# Altium schematic sheet MM-Esp32S3.SchDoc
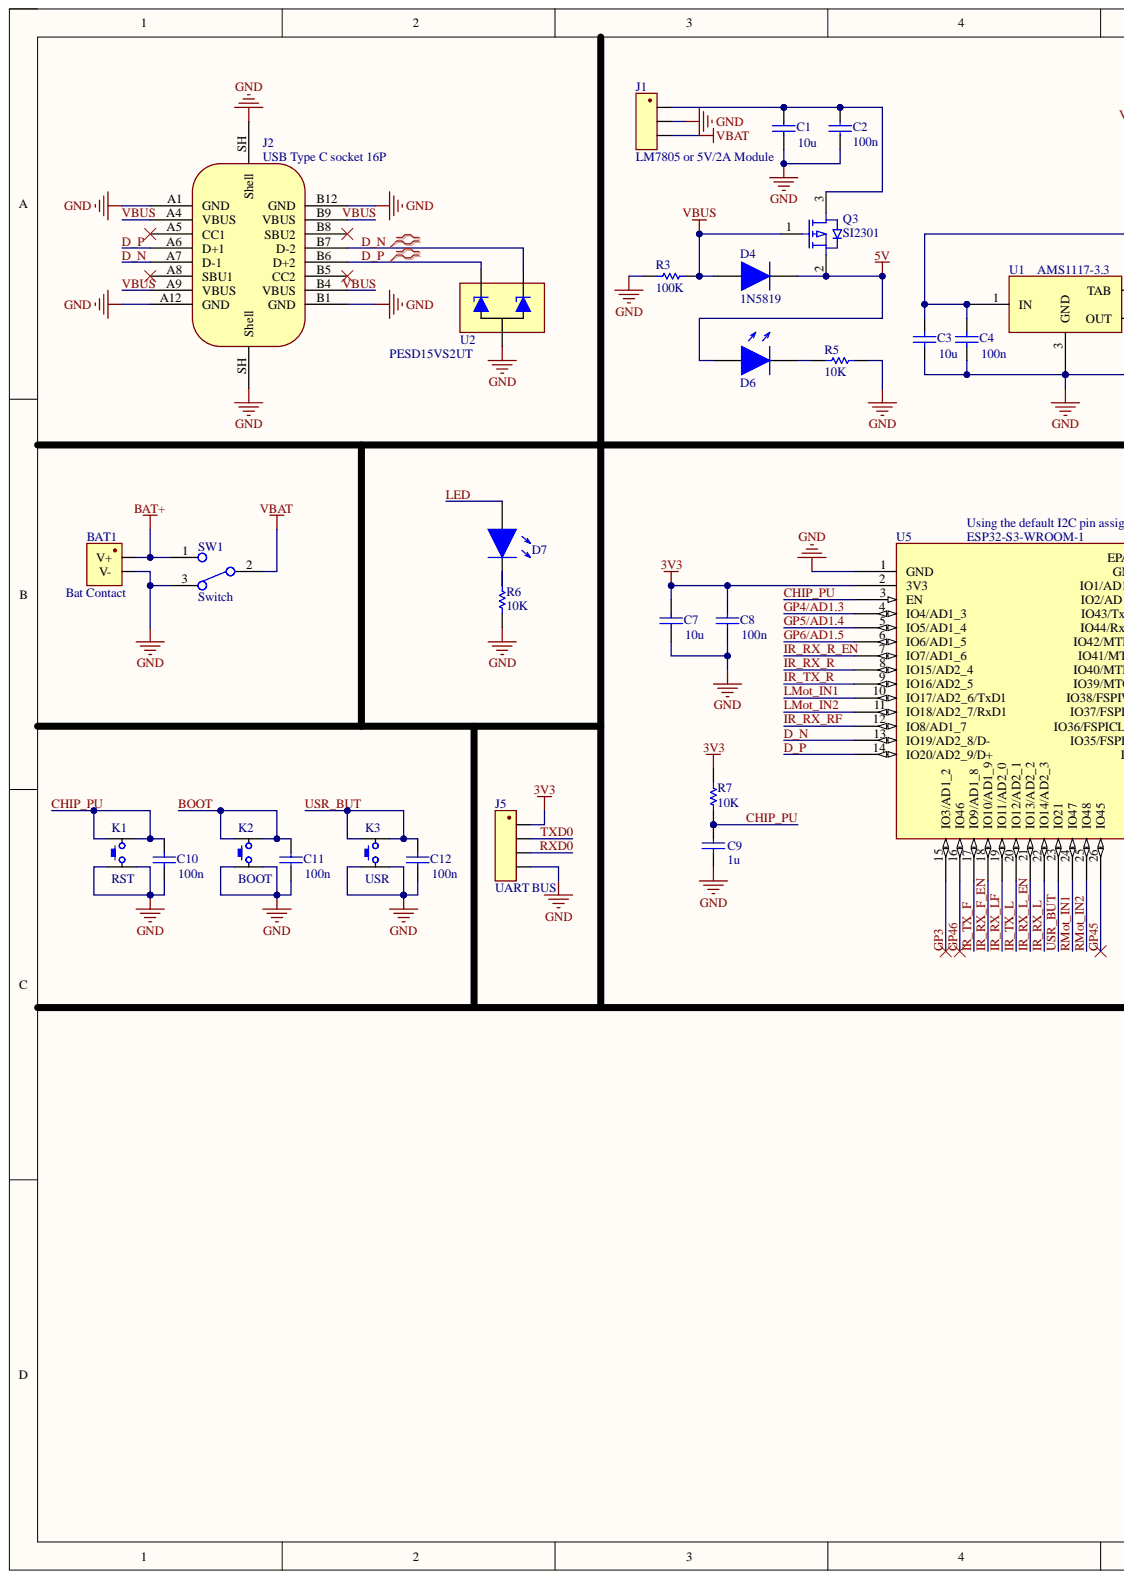
[diagram p0: MM-Esp32S3.SchDoc, left]
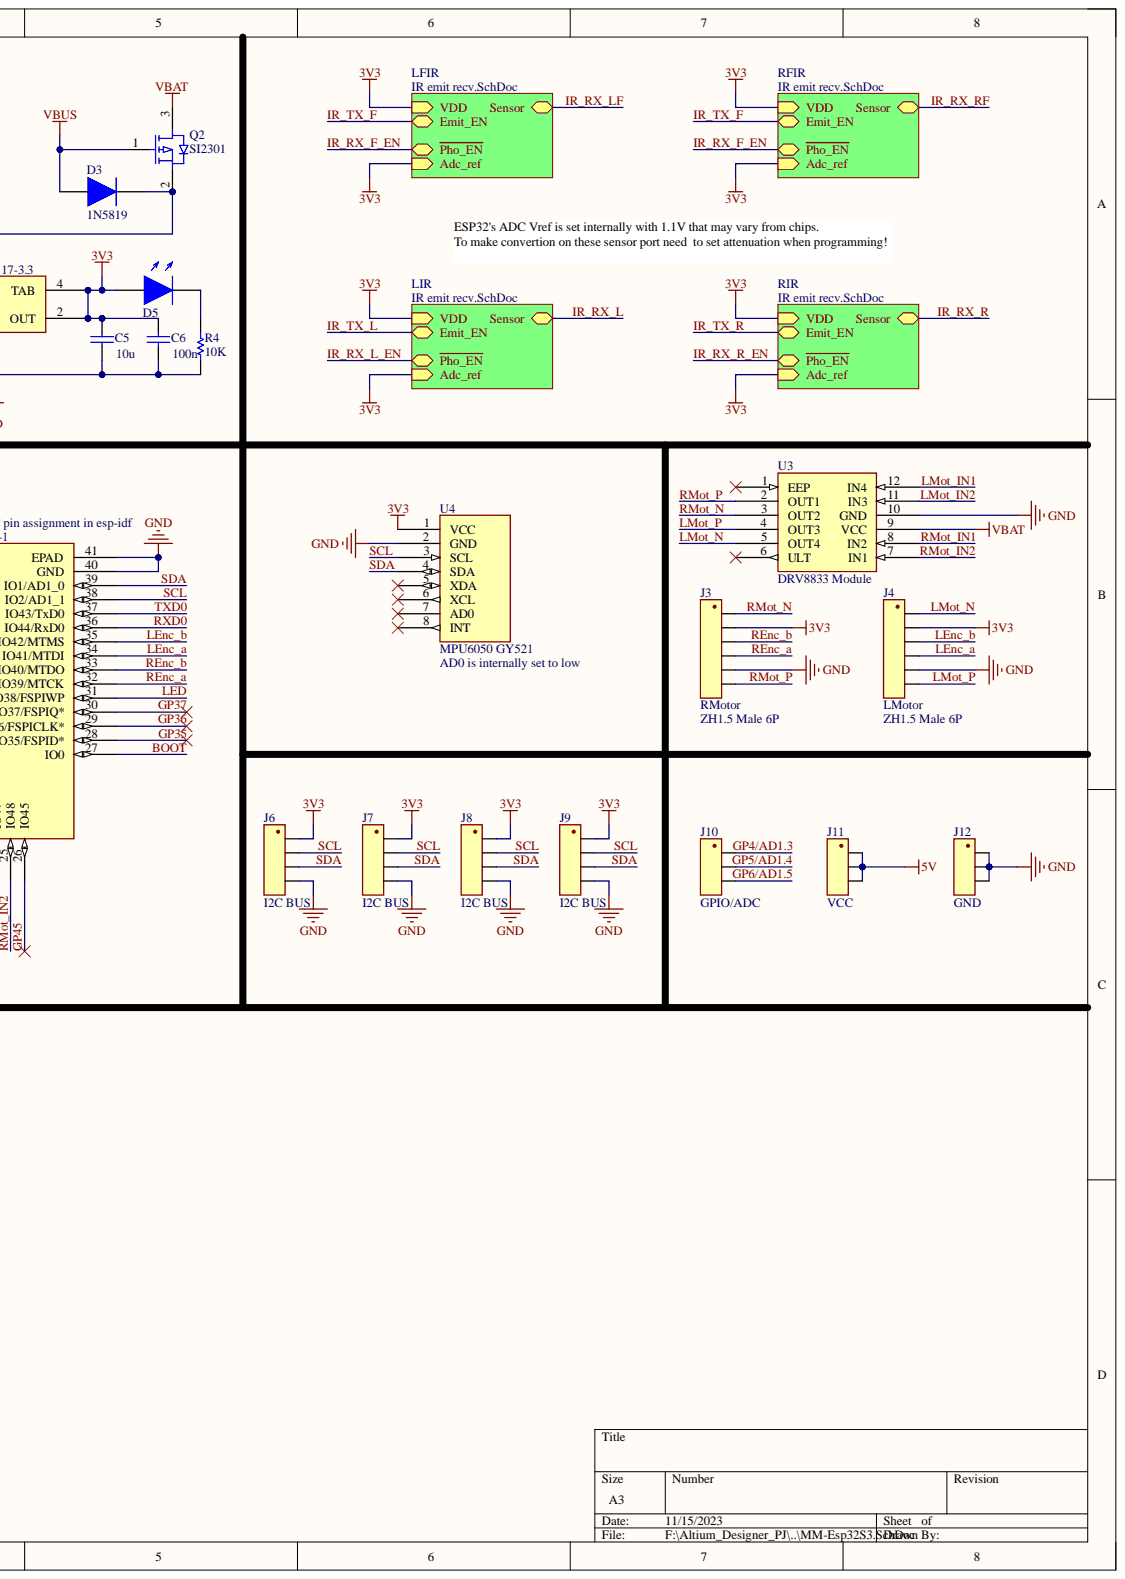
[diagram p1: MM-Esp32S3.SchDoc, right]

## Parts
BAT1  Bat Contact  [9V BAT SNAP-ON 2 CONTACTS MF]
C1  value 10u  [0805 Cap]  (hidden comment: Cap)
C2  value 100n  [0805 Cap]  (hidden comment: Cap)
C3  value 10u  [0805 Cap]  (hidden comment: Cap)
C4  value 100n  [0805 Cap]  (hidden comment: Cap)
C5  value 10u  [0805 Cap]  (hidden comment: Cap)
C6  value 100n  [0805 Cap]  (hidden comment: Cap)
C7  value 10u  [0805 Cap]  (hidden comment: Cap)
C8  value 100n  [0805 Cap]  (hidden comment: Cap)
C9  value 1u  [0805 Cap]  (hidden comment: Cap)
C10  value 100n  [0805 Cap]  (hidden comment: Cap)
C11  value 100n  [0805 Cap]  (hidden comment: Cap)
C12  value 100n  [0805 Cap]  (hidden comment: Cap)
D3  1N5819  [SOD323 0805]
D4  1N5819  [SOD323 0805]
D5  LED  [LED 3mm]  (value not drawn)
D6  LED  [LED 3mm]  (value not drawn)
D7  LED  [LED 3mm]
J1  LM7805 or 5V/2A Module  [Header 3Pins Female DIP]
J2  USB Type C socket 16P  [USB Type C 16P Through hole]
J3  RMotor  Size: ZH1.5 Male 6P  [ZH1.5 6PINS DIP]
J4  LMotor  Size: ZH1.5 Male 6P  [ZH1.5 6PINS DIP]
J5  UART BUS  [Header 4Pins DIP]
J6  I2C BUS  [Header 4Pins DIP]
J7  I2C BUS  [Header 4Pins DIP]
J8  I2C BUS  [Header 4Pins DIP]
J9  I2C BUS  [Header 4Pins DIP]
J10  GPIO/ADC  [Header 3Pins DIP]
J11  VCC  [Header 3Pins DIP]
J12  GND  [Header 3Pins DIP]
K1  RST  [BUTTON TH 6*6*5]
K2  BOOT  [BUTTON TH 6*6*5]
K3  USR  [BUTTON TH 6*6*5]
Q2  SI2301  [SOT23-3]
Q3  SI2301  [SOT23-3]
R3  value 100K  [0805 R/L]  (hidden comment: Res)
R4  value 10K  [0805 R/L]  (hidden comment: Res)
R5  value 10K  [0805 R/L]  (hidden comment: Res)
R6  value 10K  [0805 R/L]  (hidden comment: Res)
R7  value 10K  [0805 R/L]  (hidden comment: Res)
SW1  Switch  [Slide switch]
U1  AMS1117-3.3  [SOT-223]
U2  PESD15VS2UT  [SOT23-3]
U3  DRV8833 Module  [DRV8833 MODULE]
U4  MPU6050 GY521  [MPU6050 Module]
U5  ESP32-S3-WROOM-1  [ESP32-WROOM]

## Nets
3V3: C5.2 C6.2 C7.2 C8.2 D5.2 J3.2 J4.2 J5.1 J6.1 J7.1 J8.1 J9.1 R7.1 U1.2(OUT) U1.4(TAB) U4.1(VCC) U5.2(3V3)
5V: D4.2 D6.2 J11.1 J11.2 J11.3 Q3.2
BAT+: BAT1.1 SW1.1
GND: BAT1.2 C1.1 C2.1 C3.1 C4.1 C5.1 C6.1 C7.1 C8.1 C9.1 C10.1 C11.1 C12.1 J1.2 J2.A1(GND) J2.A12(GND) J2.B1(GND) J2.B12(GND) J2.SH(Shell) J3.5 J4.5 J5.4 J6.4 J7.4 J8.4 J9.4 J12.1 J12.2 J12.3 K1.3 K1.4 K2.3 K2.4 K3.3 K3.4 R3.2 R4.2 R5.2 R6.2 SW1.3 U1.3(GND) U2.3 U3.10(GND) U4.2(GND) U5.1(GND) U5.40(GND) U5.41(EPAD)
VBAT: J1.3 Q2.3 SW1.2 U3.9(VCC)
BOOT: C11.2 K2.1 K2.2 U5.27(IO0)
CHIP_PU: C9.2 C10.2 K1.1 K1.2 R7.2 U5.3(EN)
D_N: J2.A7(D-1) J2.B7(D-2) U2.1 U5.13(IO19/AD2_8/D-)
D_P: J2.A6(D+1) J2.B6(D+2) U2.2 U5.14(IO20/AD2_9/D+)
GP3: U5.15(IO3/AD1_2)
GP4/AD1.3: J10.1 U5.4(IO4/AD1_3)
GP5/AD1.4: J10.2 U5.5(IO5/AD1_4)
GP6/AD1.5: J10.3 U5.6(IO6/AD1_5)
GP35: U5.28(IO35/FSPID*)
GP36: U5.29(IO36/FSPICLK*)
GP37: U5.30(IO37/FSPIQ*)
GP45: U5.26(IO45)
GP46: U5.16(IO46)
IR_RX_F_EN: U5.18(IO10/AD1_9)
IR_RX_L: U5.22(IO14/AD2_3)
IR_RX_L_EN: U5.21(IO13/AD2_2)
IR_RX_LF: U5.19(IO11/AD2_0)
IR_RX_R: U5.8(IO15/AD2_4)
IR_RX_R_EN: U5.7(IO7/AD1_6)
IR_RX_RF: U5.12(IO8/AD1_7)
IR_TX_F: U5.17(IO9/AD1_8)
IR_TX_L: U5.20(IO12/AD2_1)
IR_TX_R: U5.9(IO16/AD2_5)
LED: D7.2 U5.31(IO38/FSPIWP)
LEnc_a: J4.4 U5.34(IO41/MTDI)
LEnc_b: J4.3 U5.35(IO42/MTMS)
LMot_IN1: U3.12(IN4) U5.10(IO17/AD2_6/TxD1)
LMot_IN2: U3.11(IN3) U5.11(IO18/AD2_7/RxD1)
LMot_N: J4.1 U3.5(OUT4)
LMot_P: J4.6 U3.4(OUT3)
REnc_a: J3.4 U5.32(IO39/MTCK)
REnc_b: J3.3 U5.33(IO40/MTDO)
RMot_IN1: U3.8(IN2) U5.24(IO47)
RMot_IN2: U3.7(IN1) U5.25(IO48)
RMot_N: J3.1 U3.3(OUT2)
RMot_P: J3.6 U3.2(OUT1)
RXD0: J5.3 U5.36(IO44/RxD0)
SCL: J6.2 J7.2 J8.2 J9.2 U4.3(SCL) U5.38(IO2/AD1_1)
SDA: J6.3 J7.3 J8.3 J9.3 U4.4(SDA) U5.39(IO1/AD1_0)
TXD0: J5.2 U5.37(IO43/TxD0)
USR_BUT: C12.2 K3.1 K3.2 U5.23(IO21)
VBUS: D3.1 D4.1 J2.A4(VBUS) J2.A9(VBUS) J2.B4(VBUS) J2.B9(VBUS) Q2.1 Q3.1 R3.1
NetC1_2: C1.2 C2.2 J1.1 Q3.3
NetC3_2: C3.2 C4.2 D3.2 Q2.2 U1.1(IN)
NetD5_1: D5.1 R4.1
NetD6_1: D6.1 R5.1
NetD7_1: D7.1 R6.1
NetJ2_A5: J2.A5(CC1)
NetJ2_A8: J2.A8(SBU1)
NetJ2_B5: J2.B5(CC2)
NetJ2_B8: J2.B8(SBU2)
NetU3_1: U3.1(EEP)
NetU3_6: U3.6(ULT)
NetU4_5: U4.5(XDA)
NetU4_6: U4.6(XCL)
NetU4_7: U4.7(AD0)
NetU4_8: U4.8(INT)

## Library data of the parts
C1-C12 = Cap: 无极电容; Component Kind: Standard; Library Name: My_Library.IntLib
D3 D4 = Diode: Component Kind: Standard; Library Name: My_Library.IntLib
D5-D7 = LED: 发光二极管; Component Kind: Standard; Library Name: My_Library.IntLib
J1 J10-J12 = Header 3pin: Component Kind: Standard; Library Name: My_Library.IntLib
J2 = USB Type C socket 16P: USB Type C母座 16P; Component Kind: Standard; Library Name: My_Library.IntLib
J3 J4 = Header 6pin: Component Kind: Standard; Library Name: My_Library.IntLib
J5-J9 = Header 4pin: Component Kind: Standard; Library Name: My_Library.IntLib
K1-K3 = Button: 按键开关; Component Kind: Standard; Library Name: My_Library.IntLib
Q2 Q3 = PMOS: Component Kind: Standard; Library Name: My_Library.IntLib
SW1 = Switch: 开关 拨动开关 滑动开关; Component Kind: Standard; Library Name: My_Library.IntLib
U1 = AMS1117: Component Kind: Standard; Library Name: My_Library.IntLib
U2 = PESD15VS2UT: 静电放电(ESD)保护器件; Component Kind: Standard; Library Name: My_Library.IntLib
U3 = DRV8833 Module: DRV8833两路电机驱动模块  https://detail.tmall.com/item.htm?id=543193414673; Component Kind: Standard; Library Name: My_Library.IntLib
U4 = MPU6050 GY521: 淘宝上最常用的8针脚MPU6050; Component Kind: Standard; Library Name: My_Library.IntLib
U5 = ESP32-S3-WROOM-1: 乐鑫ESP32S3Wroom模组  内置芯片为ESP32-S3R8的模组中,*管脚只能用于连至内部的OSPI PSRAM; Component Kind: Standard; Library Name: My_Library.IntLib
BAT1 = Bat Contact: Component Kind: Standard; Library Name: My_Library.IntLib
R3-R7 = Res: Component Kind: Standard; Library Name: My_Library.IntLib
